# Altium schematic sheet VUB_Gripper_Interface.SchDoc
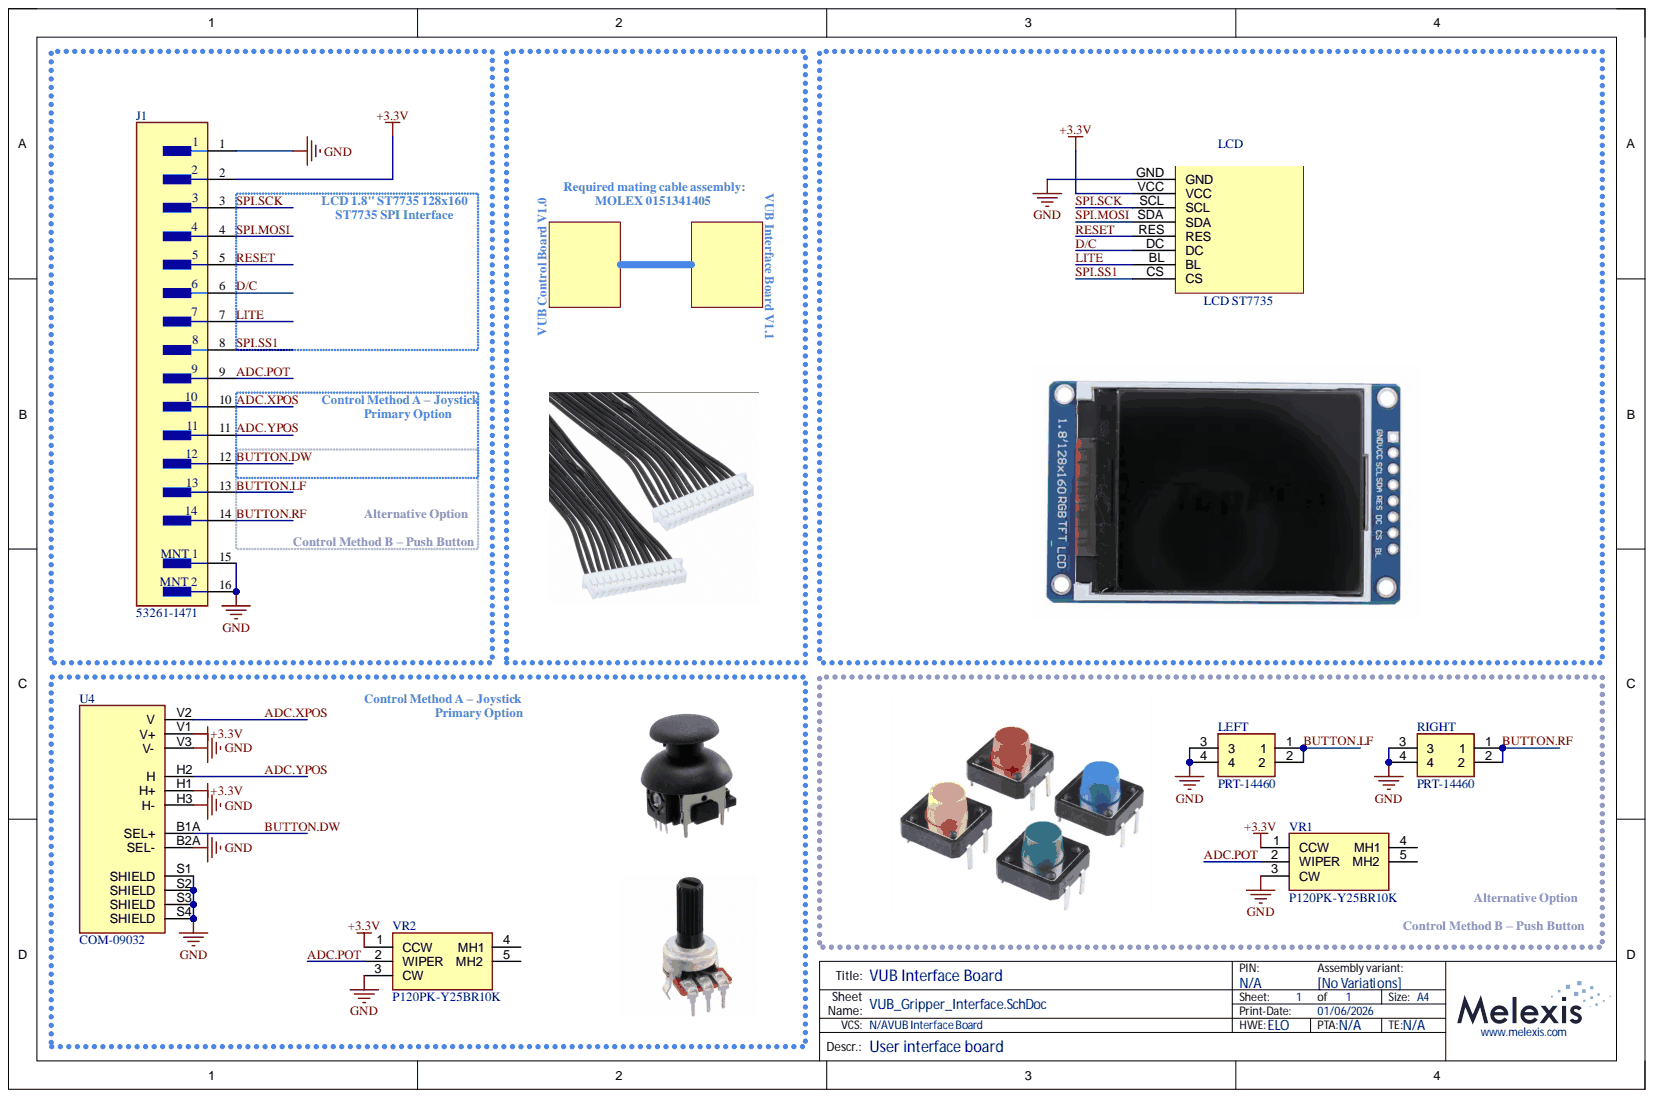
[diagram p0: VUB_Gripper_Interface.SchDoc]

## Parts
J1  53261-1471  [FP-53261-1471-MFG]
LCD  LCD ST7735  [PCBComponent_1]
LEFT  PRT-14460  [PRT14460]
RIGHT  PRT-14460  [PRT14460]
U4  COM-09032  [XDCR_COM-09032]
VR1  P120PK-Y25BR10K  [P120PKY25BR10K]
VR2  P120PK-Y25BR10K  [P120PKY25BR10K]

## Nets
+3.3V: J1.2 LCD.VCC U4.H1(H+) U4.V1(V+) VR1.1(CCW) VR2.1(CCW)
GND: J1.1 J1.15 J1.16 LCD.GND LEFT.3 LEFT.4 RIGHT.3 RIGHT.4 U4.B2A(SEL-) U4.H3(H-) U4.S1(SHIELD) U4.S2(SHIELD) U4.S3(SHIELD) U4.S4(SHIELD) U4.V3(V-) VR1.3(CW) VR2.3(CW)
ADC.POT: J1.9 VR1.2(WIPER) VR2.2(WIPER)
ADC.XPOS: J1.10 U4.V2(V)
ADC.YPOS: J1.11 U4.H2(H)
BUTTON.DW: J1.12 U4.B1A(SEL+)
BUTTON.LF: J1.13 LEFT.1 LEFT.2
BUTTON.RF: J1.14 RIGHT.1 RIGHT.2
D/C: J1.6 LCD.DC
LITE: J1.7 LCD.BL
RESET: J1.5 LCD.RES
SPI.MOSI: J1.4 LCD.SDA
SPI.SCK: J1.3 LCD.SCL
SPI.SS1: J1.8 LCD.CS

Not connected: VR1 4(MH1) 5(MH2); VR2 4(MH1) 5(MH2)

## Library data of the parts
J1 = CMP-04776-000243-1: CONN HEADER SMD R/A 14POS 1.25MM; Body Plating: Tin; Contact Resistance: 20mR; Current Rating: 1A; Gender: Male; Glow Wire Compliant: Non-compliant; Insulation Resistance: 100MR; Number of Circuits: 14; Voltage Rating: 125V; Color: Beige; Component Kind: Standard; Contact Material: Bronze; Contact Plating: Tin; Height: 3.4mm; Housing Material: Thermoplastic; Lead Free: Lead Free; Lead Pitch: 1.25mm; Library Name: Altium Content Vault; Max Operating Temperature: 85°C; Min Operating Temperature: -40°C; Mount: Surface Mount; Number of Contacts: 14; Number of Positions: 14; Number of Rows: 1; Orientation: Right Angle; Package Quantity: 1000; Packaging: Tape and Reel; Pitch: 1.25mm; Radiation Hardening: No; RoHS Compliant: Yes; Series: PicoBlade; Termination: SMD/SMT; Voltage Rating (AC): 125V; Voltage Rating (DC): 125V; Working Voltage: 125V
LCD = Component_1: Component Kind: Standard; Library Name: LCDLib.SchLib
LEFT RIGHT = PRT-14460: Switch; Manufacturer_Name: SparkFun; Arrow Part Number: PRT-14460; Arrow Price/Stock: https://www.arrow.com/en/products/prt-14460/sparkfun-electronics; Component Kind: Standard; Datasheet Link: https://cdn.sparkfun.com/assets/8/2/4/e/9/MulticolorButtonModel.pdf?_gl=1*160btra*_ga*MjAzNDIzNDYwNy4xNzAzMzQyMjUz*_ga_T369JS7J9N*MTcwNTg5OTIyOC4zLjEuMTcwNTg5OTMwMy42MC4wLjA.; Height: 8.2mm; Manufacturer_Part_Number: PRT-14460; Mouser Part Number: 474-PRT-14460; Mouser Price/Stock: https://www.mouser.com/Search/Refine.aspx?Keyword=474-PRT-14460
U4 = COM-09032: Thumb Joystick; Availability: Unavailable; Component Kind: Standard; Library Name: COM-09032.IntLib; MP: COM-09032; Package: None; Price: None
VR1 VR2 = P120PK-Y25BR10K: Variable Resistor; Manufacturer_Name: TT Electronics; Arrow Part Number: P120PK-Y25BR10K; Arrow Price/Stock: https://www.arrow.com/en/products/p120pk-y25br10k/bi-technologies.html?utm_currency=USD&region=nac; Component Kind: Standard; Datasheet Link: https://www.ttelectronics.com/TTElectronics/media/ProductFiles/Datasheet/P120.pdf; Height: 25.5mm; Manufacturer_Part_Number: P120PK-Y25BR10K; Mouser Part Number: 858-P120PKY25BR10K; Mouser Price/Stock: https://www.mouser.co.uk/ProductDetail/BI-Technologies-TT-Electronics/P120PK-Y25BR10K?qs=mJjUQczmFnjx7VJQOQfC3g%3D%3D
